# Altium schematic sheet SH3 - 17V BUCK CONVERTER.SchDoc
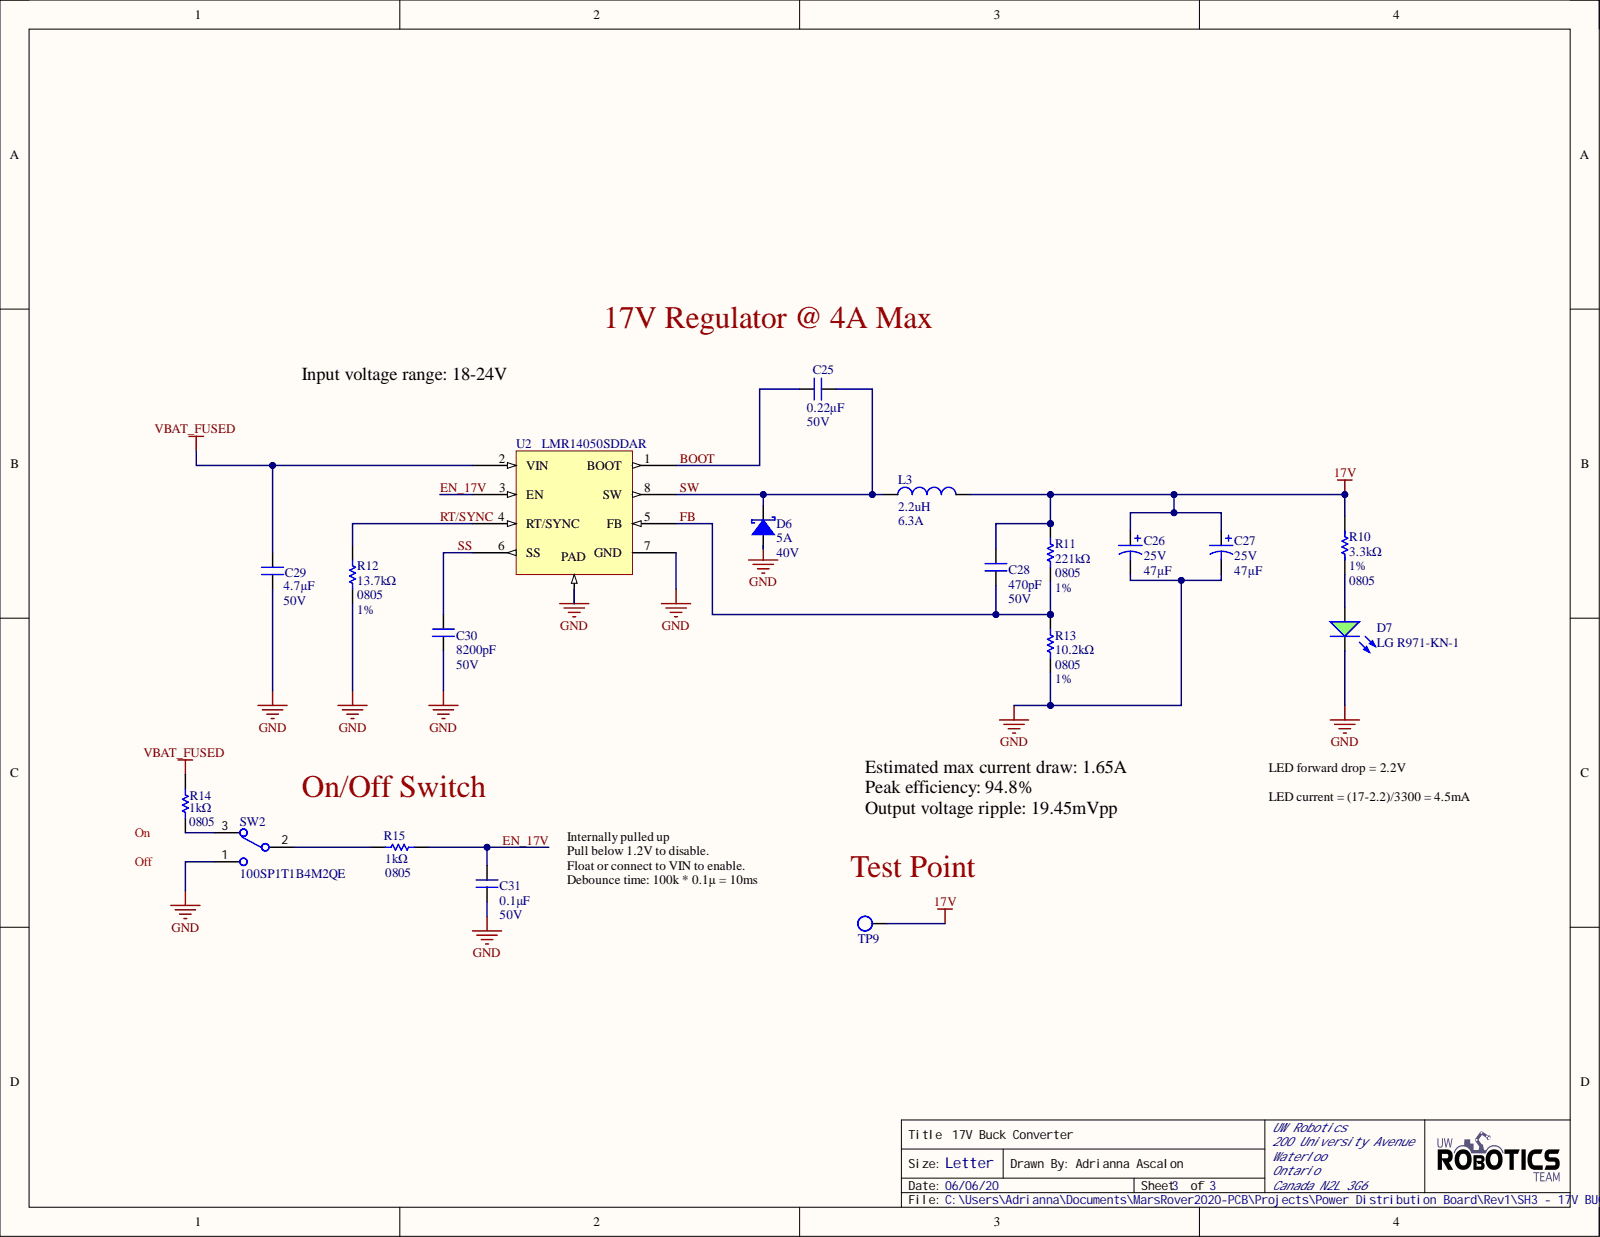
[diagram p0: SH3 - 17V BUCK CONVERTER.SchDoc]

## Parts
C25  Capacitance: 0.22µF  Voltage Rating: 50V  [CAP 0805_2012]  (hidden comment: GRM21BR71H224MA01L)
C26  47μF  Voltage: 25V  [25SVPF47M]
C27  47μF  Voltage: 25V  [25SVPF47M]
C28  470pF  Voltage Rating: 50V  [CAP 0805_2012]
C29  value 4.7µF  Capacitance: 4.7µF  Voltage: 50V  [CAPC1206(3216)]  (hidden comment: GRM31CR71H475KA12L)
C30  8200pF  Voltage Rating: 50V  [CAP 0805_2012]
C31  0.1μF  Voltage Rating: 50V  [CAPC2012X140N]
D6  Average Rectified Current: 5A  Max Repetitive Reverse Voltage (Vrrm): 40V  [DO214AB SMC]  (hidden comment: B540C-13-F)
D7  LG R971-KN-1  [LED_0805_LINE]
L3  Current Rating: 6.3A  Inductance: 2.2uH  [IND_BOURNS_SRN80]  (hidden comment: SRN8040-2R2Y)
R10  3.3kΩ  Case Code (Imperial): 0805  Tolerance: 1%  [RESC2012X60N]
R11  221kΩ  Case Code (Imperial): 0805  Tolerance: 1%  [RESC2012X60N]
R12  13.7kΩ  Case Code (Imperial): 0805  Tolerance: 1%  [RESC2012X60N]
R13  10.2kΩ  Case Code (Imperial): 0805  Tolerance: 1%  [RESC2012X60N]
R14  1kΩ  Case Code (Imperial): 0805  [RESC2012X60N]
R15  1kΩ  Case Code (Imperial): 0805  [RESC2012X60N]
SW2  100SP1T1B4M2QE  [100SP1T1B4M2QE]
TP9  TESTPOINT_LOOP  [TESTPOINT_LOOP]  (value not drawn)
U2  LMR14050SDDAR  [8-PowerSOIC]

## Nets
17V: C26.1 C27.1 C28.2 L3.2 R10.2 R11.2 TP9.1
GND: C26.2 C27.2 C29.2 C30.1 C31.1 D6.1 D7.2 R12.1 R13.1 SW2.1 U2.7(GND) U2.9
VBAT_FUSED: C29.1 R14.2 U2.2(VIN)
BOOT: C25.2 U2.1(BOOT)
EN_17V: C31.2 R15.2 U2.3(EN)
FB: C28.1 R11.1 R13.2 U2.5(FB)
RT/SYNC: R12.2 U2.4(RT/SYNC)
SS: C30.2 U2.6(SS)
SW: C25.1 D6.2 L3.1 U2.8(SW)
NetD7_1: D7.1 R10.1
NetR14_1: R14.1 SW2.3
NetR15_1: R15.1 SW2.2

## Library data of the parts
C25 = GRM21BR71H224MA01L: CAP CER 0.22UF 50V X7R 0805; Manufacturer 1: Murata; Tolerance: 20%; Case Code (Imperial): 0805; Case Code (Metric): 2012; Case/Package: 0805; Component Kind: Standard; Depth: 1.25mm; Dielectric: X7R; Height: 1.25mm; Length: 2mm; Manufacturer Part Number 1: GRM21BR71H224MA01L; Max Operating Temperature: 125°C; Min Operating Temperature: -55°C; Mount: Surface Mount; Package: 0805 (2012 Metric); Supplier 1: Digi-Key; Supplier Part Number 1: 490-1671-1-ND; Termination: SMD/SMT; Thickness: 0.053inch; Voltage Rating (DC): 50V; Width: 0.049inch; Library Name: UWRT_Schematic_Library_2020.SchLib
C26 C27 = 25SVPF47M: CAP ALUM POLY 47UF 20% 25V SMD; Manufacturer 1: Panasonic; Breakdown Voltage: 24.4V; Clamping Voltage: 35.5V; Current: 113A; Direction: Unidirectional; Dissipation Factor: 12%; Element Configuration: Single; ESR (Equivalent Series Resistance): 30mR; Leakage Current: 235uA; Life (Hours): 5000Hour; Max Breakdown Voltage: 26.9V; Max Forward Surge Current (Ifsm): 40A; Max Power Dissipation: 400W; Max Reverse Leakage Current: 1uA; Max Surge Current: 11.3A; Number of Channels: 1; Operating Supply Voltage: 22V; Polarity: Polar; Ripple Current: 2.8A; Test Current: 1mA; Working Voltage: 3.5V; Capacitance: 47µF; Case/Package: Radial; Component Kind: Standard; Diameter: 6.3mm; Height: 5.9mm; Height - Seated (Max): 0.236inch; Length: 5.9mm; Manufacturer Part Number 1: 25SVPF47M; Max Operating Temperature: 105°C; Min Breakdown Voltage: 24.4V; Min Operating Temperature: -55°C; Mount: Surface Mount; Number of Elements: 1; Number of Pins: 6; Peak Pulse Current: 11.3A; Peak Pulse Power: 400W; Power Dissipation: 400W; REACH SVHC: No SVHC; Reverse Breakdown Voltage: 24.4V; Reverse Standoff Voltage: 22V; Ripple Current (AC): 2.8A; Supplier 1: Digi-Key; Supplier Part Number 1: P16513CT-ND; Termination: SMD/SMT; Tolerance: 20%; Voltage Rating: 25V; Voltage Rating (DC): 25V; Width: 0.11inch; Library Name: UWRT_Schematic_Library_2020.SchLib
C28 = CAP_470pF_50V_0805: CAP CER 470PF 50V X7R 0805; Manufacturer 1: Kyocera AVX; Manufacturer Part Number 1: 08055C471JAT2A; Dissipation Factor: 2.5%; Tolerance: 5%; Capacitance: 470pF; Case Code (Imperial): 0805; Case Code (Metric): 2012; Component Kind: Standard; ComponentLink7Description: https://datasheet.ciiva.com/14906/cx7r-14906253.pdf?src-supplier=Digi-Key; ComponentLink7URL: https://datasheet.ciiva.com/14906/cx7r-14906253.pdf?src-supplier=Digi-Key; Contact Plating: Tin,Matte; Depth: 1.25mm; Dielectric: X7R; Height: 0.94mm; Length: 2.01mm; Max Operating Temperature: 125°C; Min Operating Temperature: -55°C; Mount: Surface Mount; Number of Pins: 2; Package: 0805 (2012 Metric); REACH SVHC: Unknown; Supplier 1: Digi-Key; Supplier Part Number 1: 478-7958-1-ND; Termination: SMD/SMT; Thickness: 0.037inch; Voltage Rating (DC): 50V; Width: 0.049inch; Library Name: UWRT_Schematic_Library_2020.SchLib
C29 = GRM31CR71H475KA12L: CAP CER 4.7UF 50V X7R 1206; Manufacturer 1: Murata; Material: Ceramic; Tolerance: 10%; Case Code (Imperial): 1206; Case Code (Metric): 3216; Case/Package: 1206; Component Kind: Standard; ComponentLink7Description: https://datasheet.ciiva.com/6270/partnumbering-e-01-6270218.pdf?src-supplier=Digi-Key; ComponentLink7URL: https://datasheet.ciiva.com/6270/partnumbering-e-01-6270218.pdf?src-supplier=Digi-Key; ComponentLink8Description: https://datasheet.ciiva.com/6270/partnumbering-e-01-6270219.pdf?src-supplier=Digi-Key; ComponentLink8URL: https://datasheet.ciiva.com/6270/partnumbering-e-01-6270219.pdf?src-supplier=Digi-Key; Depth: 1.6mm; Dielectric: X7R; Height: 1.6mm; Length: 3.2mm; Manufacturer Part Number 1: GRM31CR71H475KA12L; Max Operating Temperature: 125°C; Min Operating Temperature: -55°C; Mount: Surface Mount; Package: 1206 (3216 Metric); REACH SVHC: No SVHC; Supplier 1: Digi-Key; Supplier Part Number 1: 490-6521-1-ND; Termination: SMD/SMT; Thickness: 0.071inch; Voltage Rating: 50V; Voltage Rating (DC): 50V; Width: 0.063inch; Library Name: UWRT_Schematic_Library_2020.SchLib
C30 = CAP_8200pF_50V_0805: CAP CER 8200PF 50V X7R 0805; Capacitance: 8.2nF; Tolerance: 10%; Case Code (Imperial): 0805; Case Code (Metric): 2012; Component Kind: Standard; Depth: 1.25mm; Dielectric: X7R; Length: 0.079inch; Max Operating Temperature: 125°C; Min Operating Temperature: -55°C; Mount: Surface Mount; Number of Pins: 2; Package: 0805 (2012 Metric); Ratings: AEC-Q200; Supplier 1: Digi-Key; Supplier Part Number 1: 478-9924-6-ND; Termination: SMD/SMT; Thickness: 0.037inch; Voltage Rating (DC): 50V; Width: 0.049inch; Library Name: UWRT_Schematic_Library_2020.SchLib
C31 = CAP_0.1uF_50V_0805: CAP CER 0.1UF 50V X7R 0805; Manufacturer 1: Murata Electronics; Manufacturer Part Number 1: GCD21BR71H104KA01L; Tolerance: 10%; Case Code (Imperial): 0805; Case Code (Metric): 2012; Component Kind: Standard; Depth: 1.25mm; Dielectric: X7R; Height: 1.25mm; Length: 2mm; Max Operating Temperature: 125°C; Min Operating Temperature: -55°C; Mount: Surface Mount; Package: 0805 (2012 Metric); Ratings: AEC-Q200; Supplier 1: Digi-Key; Supplier Part Number 1: 490-11955-1-ND; Termination: SMD/SMT; Thickness: 0.049inch; Value: 0.1μF; Width: 0.049inch; Library Name: UWRT_Schematic_Library_2020.SchLib
D6 = B540C-13-F: DIODE SCHOTTKY 40V 5A SMC; Manufacturer 1: Diodes; Capacitance: 300pF; Element Configuration: Single; Forward Voltage: 550mV; Max Forward Surge Current (Ifsm): 100A; Peak Reverse Current: 500uA; Polarity: Standard; Case/Package: SMC; Component Kind: Standard; ComponentLink7Description: https://datasheet.ciiva.com/8303/ds13012-8303112.pdf?src-supplier=Avnet; ComponentLink7URL: https://datasheet.ciiva.com/8303/ds13012-8303112.pdf?src-supplier=Avnet; ComponentLink8Description: https://datasheet.ciiva.com/25919/ds13012-25919706.pdf?src-supplier=Avnet+Europe; ComponentLink8URL: https://datasheet.ciiva.com/25919/ds13012-25919706.pdf?src-supplier=Avnet+Europe; ComponentLink9Description: https://datasheet.ciiva.com/16200/ds13012-16200443.pdf?src-supplier=Future+Electronics; ComponentLink9URL: https://datasheet.ciiva.com/16200/ds13012-16200443.pdf?src-supplier=Future+Electronics; Contact Plating: Tin,Matte; Current: 5A; Current Rating: 5A; Forward Current: 5A; Height: 2.5mm; Length: 7.11mm; Manufacturer Part Number 1: B540C-13-F; Max Operating Temperature: 125°C; Max Reverse Voltage (DC): 40V; Min Operating Temperature: -55°C; Mount: Surface Mount; Number of Pins: 2; Output Current: 5A; Peak Non-Repetitive Surge Current: 100A; REACH SVHC: No SVHC; Supplier 1: Digi-Key; Supplier Part Number 1: B540C-FDIDKR-ND; Termination: SMD/SMT; Voltage: 40V; Voltage Rating (DC): 40V; Weight: 0.007408oz; Width: 6.22mm; Library Name: UWRT_Schematic_Library_2020.SchLib
D7 = DIO_LED_G: LED GREEN DIFFUSED 0805 SMD; Manufacturer 1: OSRAM; Forward Voltage Drop: 2.2V; Component Kind: Standard; Manufacturer Part Number 1: LG R971-KN-1; Mount: Surface Mount; Package: 0805 (2012 Metric); Simulation: LED1; Supplier 1: Digi-Key; Supplier Part Number 1: 475-1410-1-ND; Library Name: UWRT_Schematic_Library_2020.SchLib
L3 = SRN8040-2R2Y: FIXED IND 2.2UH 6.3A 13 MOHM SMD; Manufacturer 1: Bourns; Core Material: Ferrite; DC Resistance (DCR): 13mR; Inductance Tolerance: 30%; Material: Steel; Saturation Current: 7.4A; Self Resonant Frequency: 40MHz; Shielding: Shielded; Test Frequency: 100kHz; Case/Package: Nonstandard; Component Kind: Standard; ComponentLink7Description: https://datasheet.ciiva.com/1049/srn8040-1049455.pdf?src-supplier=Digi-Key; ComponentLink7URL: https://datasheet.ciiva.com/1049/srn8040-1049455.pdf?src-supplier=Digi-Key; ComponentLink8Description: https://datasheet.ciiva.com/17284/srn8040-17284783.pdf?src-supplier=Avnet+Europe; ComponentLink8URL: https://datasheet.ciiva.com/17284/srn8040-17284783.pdf?src-supplier=Avnet+Europe; ComponentLink9Description: https://datasheet.ciiva.com/48691/001056460-da-01-en-mag-abg-leist-ind-srn8040-2r2y-2-2uh-smd-48691836.pdf?src-supplier=Conrad; ComponentLink9URL: https://datasheet.ciiva.com/48691/001056460-da-01-en-mag-abg-leist-ind-srn8040-2r2y-2-2uh-smd-48691836.pdf?src-supplier=Conrad; Composition: Wirewound; Contact Plating: Tin; DC Current: 6.3A; Depth: 8.3mm; Height: 4mm; Height - Seated (Max): 0.157inch; Length: 8mm; Manufacturer Part Number 1: SRN8040-2R2Y; Max DC Current: 6.3A; Max Operating Temperature: 125°C; Military Standard: Not; Min Operating Temperature: -40°C; Mount: Surface Mount; Number of Pins: 2; REACH SVHC: No SVHC; RMS Current (Irms): 6.3A; Supplier 1: Digi-Key; Supplier Part Number 1: SRN8040-2R2YCT-ND; Termination: SMD/SMT; Tolerance: 30%; Weight: 0.028607oz; Width: 8mm; Library Name: UWRT_Schematic_Library_2020.SchLib
R10 = RES_3.3kΩ_0805: RES SMD 3.3K OHM 1% 1/8W 0805; Max Power Dissipation: 125mW; Resistance: 3.3kR; Temperature Coefficient: 100ppm/°C; Voltage Rating: 150V; Case Code (Metric): 2012; Component Kind: Standard; ComponentLink7Description: https://datasheet.ciiva.com/2467/dcrcwe3-2467823.pdf?src-supplier=Digi-Key; ComponentLink7URL: https://datasheet.ciiva.com/2467/dcrcwe3-2467823.pdf?src-supplier=Digi-Key; ComponentLink8Description: https://datasheet.ciiva.com/9080/dcrcwe3-9080591.pdf?src-supplier=Avnet; ComponentLink8URL: https://datasheet.ciiva.com/9080/dcrcwe3-9080591.pdf?src-supplier=Avnet; ComponentLink9Description: https://datasheet.ciiva.com/16430/dcrcwe3-16430277.pdf?src-supplier=Future+Electronics; ComponentLink9URL: https://datasheet.ciiva.com/16430/dcrcwe3-16430277.pdf?src-supplier=Future+Electronics; ComponentLink10Description: https://datasheet.ciiva.com/26312/fld-crcw-e3-data-en-26312934.pdf?src-supplier=Distrelec; ComponentLink10URL: https://datasheet.ciiva.com/26312/fld-crcw-e3-data-en-26312934.pdf?src-supplier=Distrelec; ComponentLink11Description: https://datasheet.ciiva.com/16778/70201119-16778680.pdf?src-supplier=Allied+Electronics; ComponentLink11URL: https://datasheet.ciiva.com/16778/70201119-16778680.pdf?src-supplier=Allied+Electronics; Composition: Thick Film; Contact Plating: Tin; Depth: 1.25mm; Features: Automotive AEC-Q200; Height: 0.45mm; Length: 2mm; Max Operating Temperature: 155°C; Military Standard: Not; Min Operating Temperature: -55°C; Mount: Surface Mount; Number of Terminations: 2; Package: 0805 (2012 Metric); Power Rating: 125mW; REACH SVHC: Unknown; Supplier 1: Digi-Key; Supplier Part Number 1: 541-3.30KHCT-ND; Termination: SMD/SMT; Voltage Rating (DC): 150V; Weight: 0.000194oz; Width: 1.25mm; Library Name: UWRT_Schematic_Library_2020.SchLib
R11 = RES_221kΩ_0805: RES SMD 221K OHM 1% 1/8W 0805; Manufacturer 1: Vishay; Manufacturer Part Number 1: CRCW0805221KFKEA; Max Power Dissipation: 125mW; Resistance: 221kR; Temperature Coefficient: 100ppm/°C; Voltage Rating: 150V; Case Code (Metric): 2012; Component Kind: Standard; ComponentLink7Description: https://datasheet.ciiva.com/2467/dcrcwe3-2467622.pdf?src-supplier=Digi-Key; ComponentLink7URL: https://datasheet.ciiva.com/2467/dcrcwe3-2467622.pdf?src-supplier=Digi-Key; ComponentLink8Description: https://datasheet.ciiva.com/3258/dcrcwe3-3258329.pdf?src-supplier=Avnet; ComponentLink8URL: https://datasheet.ciiva.com/3258/dcrcwe3-3258329.pdf?src-supplier=Avnet; ComponentLink9Description: https://datasheet.ciiva.com/7674/1533075-7674218.pdf?src-supplier=Element14; ComponentLink9URL: https://datasheet.ciiva.com/7674/1533075-7674218.pdf?src-supplier=Element14; ComponentLink10Description: https://datasheet.ciiva.com/22870/70201119-22870172.pdf?src-supplier=Allied+Electronics; ComponentLink10URL: https://datasheet.ciiva.com/22870/70201119-22870172.pdf?src-supplier=Allied+Electronics; Composition: Thick Film; Contact Plating: Tin; Depth: 1.25mm; Features: Automotive AEC-Q200; Height: 0.45mm; Length: 2mm; Max Operating Temperature: 155°C; Military Standard: Not; Min Operating Temperature: -55°C; Mount: Surface Mount; Number of Pins: 2; Number of Terminations: 2; Package: 0805 (2012 Metric); Power Rating: 125mW; REACH SVHC: Unknown; Supplier 1: Digi-Key; Supplier Part Number 1: 541-221KCCT-ND; Termination: SMD/SMT; Voltage Rating (DC): 150V; Weight: 0.000194oz; Width: 1.25mm; Library Name: UWRT_Schematic_Library_2020.SchLib
R12 = RES_13.7kΩ_0805: RES SMD 13.7K OHM 1% 1/8W 0805; Manufacturer 1: Vishay; Manufacturer Part Number 1: CRCW080513K7FKEA; Max Power Dissipation: 125mW; Resistance: 13.7kR; Temperature Coefficient: 100ppm/°C; Voltage Rating: 150V; Case Code (Metric): 2012; Component Kind: Standard; ComponentLink7Description: https://datasheet.ciiva.com/22675/1847332-22675191.pdf?src-supplier=Element14; ComponentLink7URL: https://datasheet.ciiva.com/22675/1847332-22675191.pdf?src-supplier=Element14; ComponentLink8Description: https://datasheet.ciiva.com/7663/1697337-7663928.pdf?src-supplier=Element14; ComponentLink8URL: https://datasheet.ciiva.com/7663/1697337-7663928.pdf?src-supplier=Element14; ComponentLink9Description: https://datasheet.ciiva.com/3258/dcrcwe3-3258107.pdf?src-supplier=Avnet; ComponentLink9URL: https://datasheet.ciiva.com/3258/dcrcwe3-3258107.pdf?src-supplier=Avnet; ComponentLink10Description: https://datasheet.ciiva.com/16778/70201119-16778860.pdf?src-supplier=Allied+Electronics; ComponentLink10URL: https://datasheet.ciiva.com/16778/70201119-16778860.pdf?src-supplier=Allied+Electronics; ComponentLink11Description: https://datasheet.ciiva.com/17188/dcrcwe3-17188109.pdf?src-supplier=Avnet+Europe; ComponentLink11URL: https://datasheet.ciiva.com/17188/dcrcwe3-17188109.pdf?src-supplier=Avnet+Europe; Composition: Thick Film; Contact Plating: Tin; Depth: 1.25mm; Features: Automotive AEC-Q200; Height: 0.45mm; Length: 2mm; Max Operating Temperature: 155°C; Military Standard: Not; Min Operating Temperature: -55°C; Number of Pins: 2; Number of Terminations: 2; Package: 0805 (2012 Metric); Power Rating: 125mW; REACH SVHC: Unknown; Supplier 1: Digi-Key; Supplier Part Number 1: 541-13.7KCCT-ND; Termination: SMD/SMT; Voltage Rating (DC): 150V; Weight: 0.000194oz; Width: 0.049inch; Library Name: UWRT_Schematic_Library_2020.SchLib
R13 = RES_10.2kΩ_0805: RES SMD 10.2K OHM 1% 1/8W 0805; Manufacturer 1: Vishay; Manufacturer Part Number 1: CRCW080510K2FKEA; Max Power Dissipation: 125mW; Resistance: 10.2kR; Temperature Coefficient: 100ppm/°C; Voltage Rating: 150V; Case Code (Metric): 2012; Component Kind: Standard; ComponentLink7Description: https://datasheet.ciiva.com/22702/1697337-22702902.pdf?src-supplier=Element14; ComponentLink7URL: https://datasheet.ciiva.com/22702/1697337-22702902.pdf?src-supplier=Element14; ComponentLink8Description: https://datasheet.ciiva.com/16204/dcrcwe3-16204135.pdf?src-supplier=Future+Electronics; ComponentLink8URL: https://datasheet.ciiva.com/16204/dcrcwe3-16204135.pdf?src-supplier=Future+Electronics; ComponentLink9Description: https://datasheet.ciiva.com/16852/dcrcwe3-16852825.pdf?src-supplier=Avnet+Europe; ComponentLink9URL: https://datasheet.ciiva.com/16852/dcrcwe3-16852825.pdf?src-supplier=Avnet+Europe; ComponentLink10Description: https://datasheet.ciiva.com/7663/1697337-7663915.pdf?src-supplier=Element14; ComponentLink10URL: https://datasheet.ciiva.com/7663/1697337-7663915.pdf?src-supplier=Element14; ComponentLink11Description: https://datasheet.ciiva.com/7663/1697337-7663916.pdf?src-supplier=Element14; ComponentLink11URL: https://datasheet.ciiva.com/7663/1697337-7663916.pdf?src-supplier=Element14; ComponentLink12Description: https://datasheet.ciiva.com/22674/1825492-22674606.pdf?src-supplier=Element14; ComponentLink12URL: https://datasheet.ciiva.com/22674/1825492-22674606.pdf?src-supplier=Element14; ComponentLink13Description: https://datasheet.ciiva.com/3258/dcrcwe3-3258031.pdf?src-supplier=Avnet; ComponentLink13URL: https://datasheet.ciiva.com/3258/dcrcwe3-3258031.pdf?src-supplier=Avnet; Composition: Thick Film; Contact Plating: Tin; Depth: 1.25mm; Features: Automotive AEC-Q200; Height: 0.45mm; Length: 2mm; Max Operating Temperature: 155°C; Military Standard: Not; Min Operating Temperature: -55°C; Number of Pins: 2; Number of Terminations: 2; Package: 0805 (2012 Metric); Power Rating: 125mW; REACH SVHC: Unknown; Supplier 1: Digi-Key; Supplier Part Number 1: 541-10.2KCCT-ND; Termination: SMD/SMT; Voltage Rating (DC): 150V; Weight: 0.000194oz; Width: 0.049inch; Working Voltage: 150V; Library Name: UWRT_Schematic_Library_2020.SchLib
R14 = RES_1kΩ_0805: RES SMD 1K OHM 1% 1/8W 0805; Manufacturer 1: Yageo; Manufacturer Part Number 1: RC0805FR-071KL; Max Power Dissipation: 125mW; Temperature Coefficient: 25ppm/°C; Voltage Rating: 150V; Case Code (Metric): 2012; Case/Package: 0805; Component Kind: Standard; Composition: Thin Film; Contact Plating: Tin; Depth: 1.25mm; Features: Moisture Resistant,Automotive AEC-Q200,Anti-Sulfur; Height: 0.022inch; Length: 2mm; Max Operating Temperature: 155°C; Military Standard: Not; Min Operating Temperature: -55°C; Mount: Surface Mount; Number of Pins: 2; Number of Terminations: 2; Package: 0805 (2012 Metric); Power Rating: 125mW; REACH SVHC: Unknown; Resistance: 1K; Supplier 1: Digi-Key; Supplier Part Number 1: 311-1.00KCRCT-ND; Termination: SMD/SMT; Tolerance: 1%; Value: 1kΩ; Voltage Rating (DC): 150V; Weight: 0.000194oz; Width: 0.049inch; Library Name: UWRT_Schematic_Library_2020.SchLib
R15 = RES_100kΩ_0805: RES SMD 100K OHM 0.5% 1/8W 0805; Manufacturer 1: Panasonic; Manufacturer Part Number 1: ERA-6AED104V; Power Rating: 125mW; Resistance: 100kΩ; Temperature Coefficient: 25ppm/°C; Voltage Rating: 200V; Case Code (Metric): 2012; Category: Chip SMD Resistors; Component Kind: Standard; Composition: Thin Film; Contact Plating: Tin; Depth: 1.25mm; Height: 0.5mm; Length: 2mm; Max Operating Temperature: 155°C; Military Standard: Not; Min Operating Temperature: -55°C; Mount: Surface Mount; Package: 0805 (2012 Metric); Supplier 1: Digi-Key; Supplier Part Number 1: P123795CT-ND; Termination: SMD/SMT; Tolerance: 0.5%; Width: 1.25mm; Library Name: UWRT_Schematic_Library_2020.SchLib
SW2 = SW_SPDT: SWITCH TOGGLE SPDT 5A 120V; Manufacturer 1: E-Switch; Actuator Length: 12.19mm; Actuator Material: Brass,Chrome; Actuator Type: Standard Round; Electrical Life: 40000Cycles; Illumination Type: Non-Illuminated,Illuminated; Switch Function: On-On; Voltage Rating (DC): 28V; Component Kind: Standard; Contact Material: Silver; Contact Plating: Silver; Current Rating: 5A; Manufacturer Part Number 1: 100SP1T1B4M2QE; Max Operating Temperature: 85°C; Min Operating Temperature: -30°C; Mount: Through Hole; Orientation: Vertical; Supplier 1: Digi-Key; Supplier Part Number 1: EG2355-ND; Termination: PC Pin; Throw Configuration: SPDT; Voltage Rating (AC): 120V; Library Name: UWRT_Schematic_Library_2020.SchLib
TP9 = TESTPOINT_LOOP: PC TEST POINT MINIATURE WHITE; Manufacturer 1: Keystone Electronics; Manufacturer Part Number 1: 5002; Component Kind: Standard; Mount: Through Hole; Supplier 1: Digi-Key; Supplier Part Number 1: 36-5002-ND; Library Name: UWRT_Schematic_Library_2020.SchLib
U2 = LMR14050SDDAR: IC REG BUCK ADJUSTABLE 5A 8SOPWR; Manufacturer 1: Texas Instruments; Max Input Voltage: 40V; Max Output Voltage: 28V; Min Input Voltage: 4V; Min Output Voltage: 800mV; Number of Outputs: 1; Output Configuration: Positive; Quiescent Current: 40uA; Switching Frequency: 2.5MHz; Synchronous Rectifier: No; Case/Package: 8-PowerSOIC; Component Kind: Standard; Manufacturer Part Number 1: LMR14050SDDAR; Max Operating Temperature: 125°C; Min Operating Temperature: -40°C; Mount: Surface Mount; Number of Pins: 8; Output Current: 5A; Output Type: Adjustable; Supplier 1: Digi-Key; Supplier Part Number 1: 296-44322-1-ND; Library Name: UWRT_Schematic_Library_2020.SchLib
